# Altium schematic sheet Sheet1.SchDoc(Sheet1)
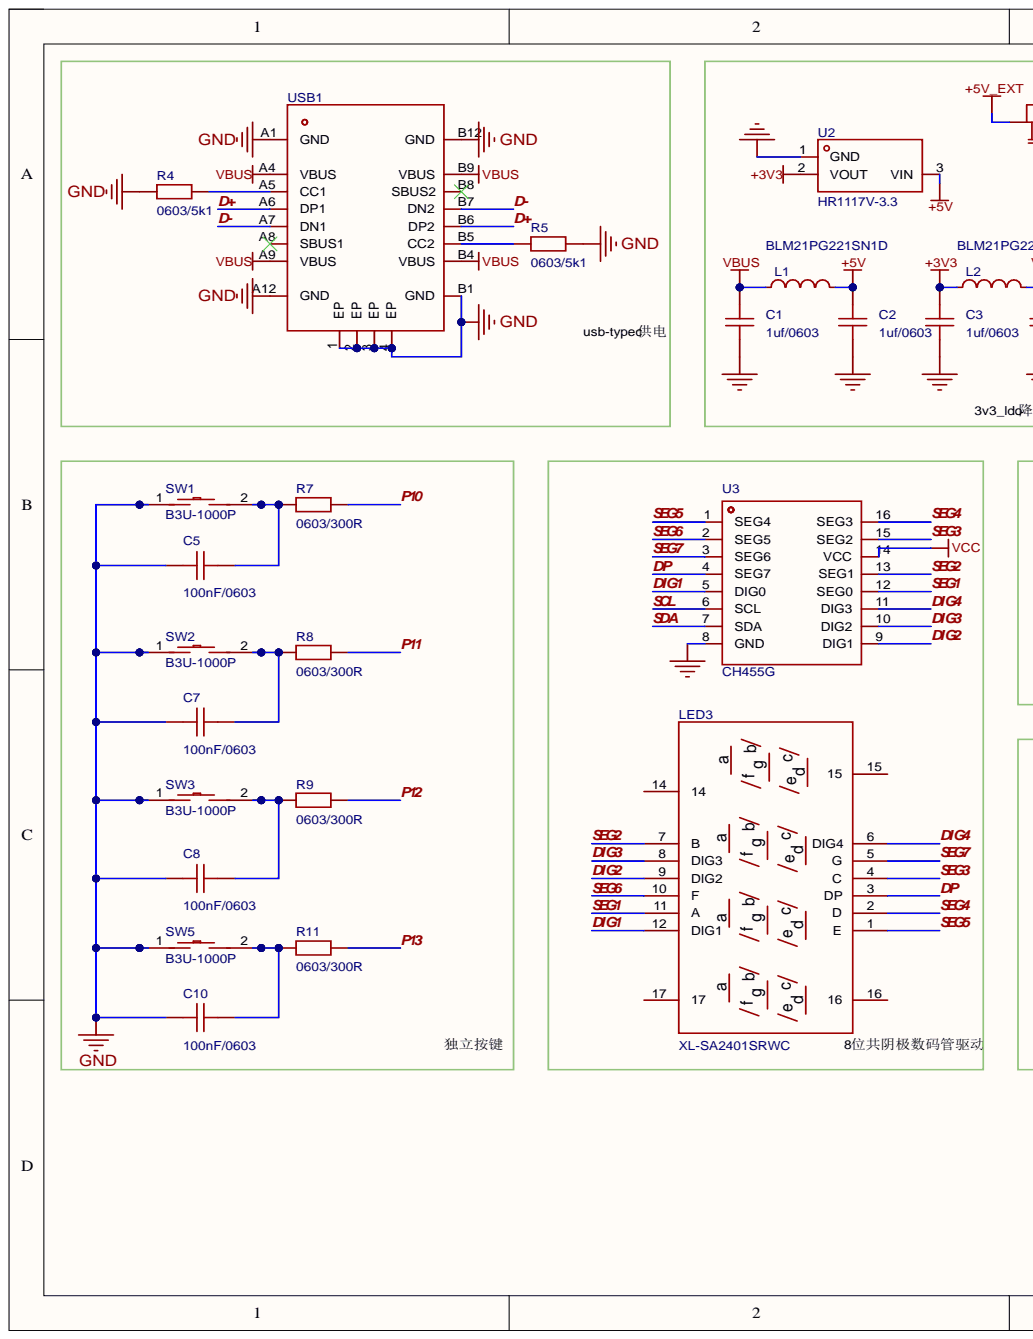
[diagram p0: Sheet1.SchDoc, left]
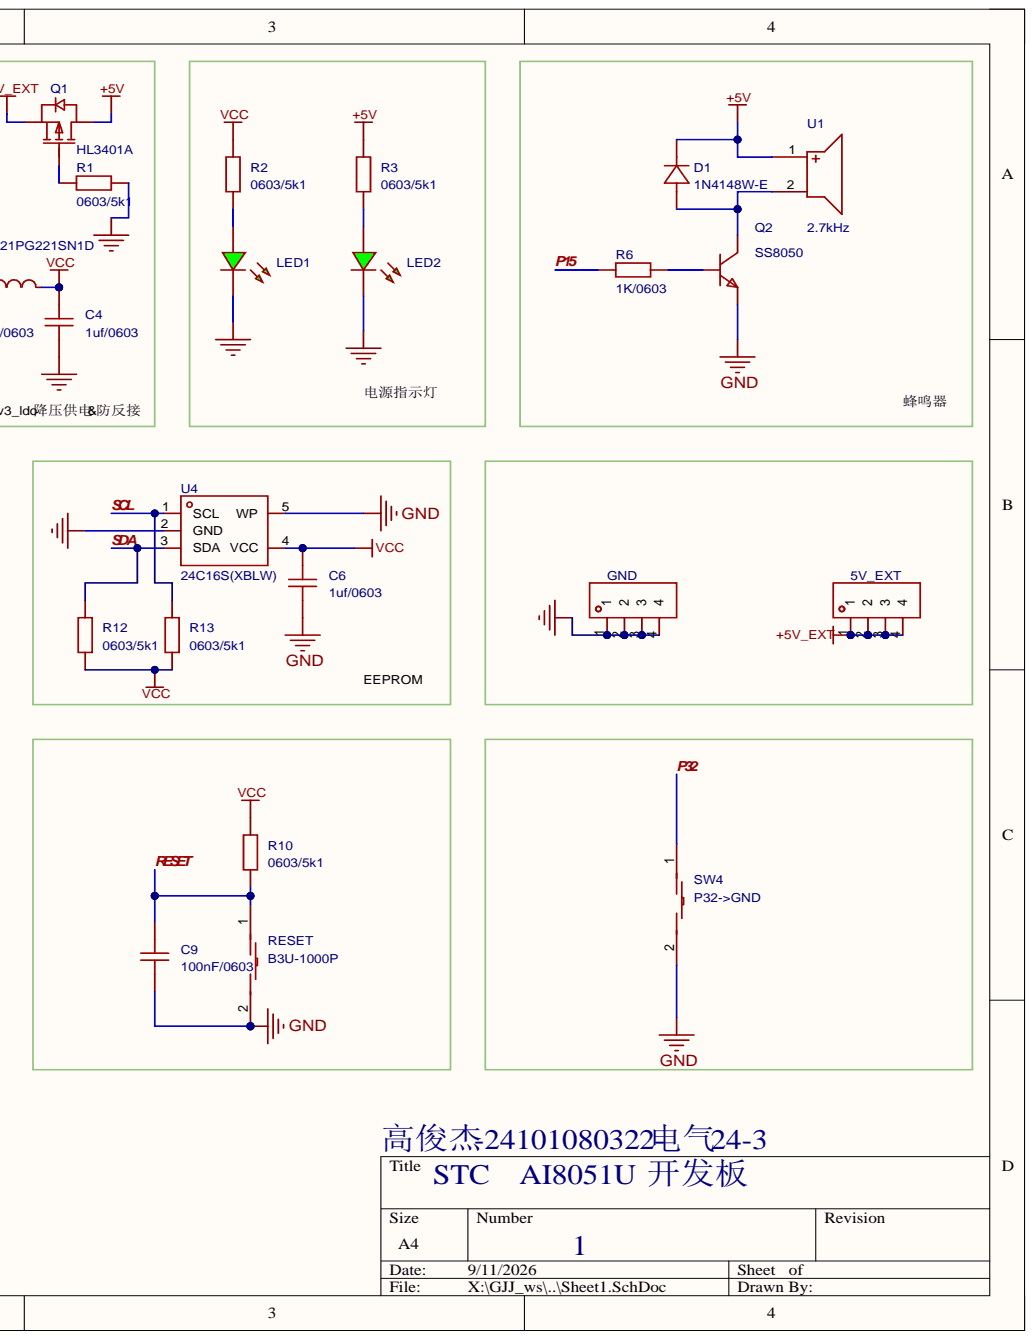
[diagram p1: Sheet1.SchDoc, right]

## Parts
5V_EXT  (no value)  [HDR-TH_4P-P2.54-V-F]
C1  1uf/0603  [C0603]
C2  1uf/0603  [C0603]
C3  1uf/0603  [C0603]
C4  1uf/0603  [C0603]
C5  100nF/0603  [C0603]
C6  1uf/0603  [C0603]
C7  100nF/0603  [C0603]
C8  100nF/0603  [C0603]
C9  100nF/0603  [C0603]
C10  100nF/0603  [C0603]
D1  1N4148W-E  [SOD-123_L2.8-W1.6-LS3.7-RD]
GND  (no value)  [HDR-TH_4P-P2.54-V-F]
L1  BLM21PG221SN1D  [L0805]
L2  BLM21PG221SN1D  [L0805]
LED1  (no value)  [LED_0603]
LED2  (no value)  [LED_0603]
LED3  XL-SA2401SRWC  [LED-SEG-SMD_12P-L28.5-W10.0-P2.54]
Q1  HL3401A  [SOT-23-3_L2.9-W1.3-P1.90-LS2.4-BR]
Q2  SS8050  [SOT-23-3_L2.9-W1.3-P1.90-LS2.4-BR]
R1  0603/5k1  [R0603]
R2  0603/5k1  [R0603]
R3  0603/5k1  [R0603]
R4  0603/5k1  [R0603]
R5  0603/5k1  [R0603]
R6  1K/0603  [R0603]
R7  0603/300R  [R0603]
R8  0603/300R  [R0603]
R9  0603/300R  [R0603]
R10  0603/5k1  [R0603]
R11  0603/300R  [R0603]
R12  0603/5k1  [R0603]
R13  0603/5k1  [R0603]
RESET  B3U-1000P  [KEY-SMD_B3U-1000PM]
SW1  B3U-1000P  [KEY-SMD_B3U-1000PM]
SW2  B3U-1000P  [KEY-SMD_B3U-1000PM]
SW3  B3U-1000P  [KEY-SMD_B3U-1000PM]
SW4  P32->GND  [KEY-SMD_B3U-1000PM]
SW5  B3U-1000P  [KEY-SMD_B3U-1000PM]
U1  2.7kHz  [BUZ-SMD_L9.7-W9.7]
U2  HR1117V-3.3  [SOT-89-3_L4.5-W2.4-P1.50-LS4.0-BR]
U3  CH455G  [SOP-16_L10.0-W3.9-P1.27-LS6.0-BL]
U4  24C16S(XBLW)  [SOT-23-5_L2.9-W1.6-P0.95-LS2.8-BL]
USB1  (no value)  [USB_TYPE-C-16P]

## Nets
+3V3: C3.2 L2.1 U2.2
+5V: C2.2 D1.1 L1.2 Q1.3 R3.2 U1.1 U2.3
+5V_EXT: 5V_EXT.1 5V_EXT.2 5V_EXT.3 5V_EXT.4 Q1.2
GND: C1.1 C2.1 C3.1 C4.1 C5.1 C6.1 C7.1 C8.1 C9.1 C10.1 GND.1 GND.2 GND.3 GND.4 LED1.1 LED2.1 Q2.2 R1.2 R4.1 R5.2 RESET.2 SW1.1 SW2.1 SW3.1 SW4.2 SW5.1 U2.1 U3.8(GND) U4.2(GND) U4.5(WP) USB1.1(EP) USB1.2(EP) USB1.3(EP) USB1.4(EP) USB1.A1(GND) USB1.A12(GND) USB1.B1(GND) USB1.B12(GND)
VBUS: C1.2 L1.1 USB1.A4(VBUS) USB1.A9(VBUS) USB1.B4(VBUS) USB1.B9(VBUS)
VCC: C4.2 C6.2 L2.2 R2.2 R10.2 R12.1 R13.1 U3.14(VCC) U4.4(VCC)
D+: USB1.A6(DP1) USB1.B6(DP2)
D-: USB1.A7(DN1) USB1.B7(DN2)
DIG1: LED3.12(DIG1) U3.5(DIG0)
DIG2: LED3.9(DIG2) U3.9(DIG1)
DIG3: LED3.8(DIG3) U3.10(DIG2)
DIG4: LED3.6(DIG4) U3.11(DIG3)
DP: LED3.3(DP) U3.4(SEG7)
P10: R7.2
P11: R8.2
P12: R9.2
P13: R11.2
P15: R6.1
P32: SW4.1
RESET: C9.2 R10.1 RESET.1
SCL: R13.2 U3.6(SCL) U4.1(SCL)
SDA: R12.2 U3.7(SDA) U4.3(SDA)
SEG1: LED3.11(A) U3.12(SEG0)
SEG2: LED3.7(B) U3.13(SEG1)
SEG3: LED3.4(C) U3.15(SEG2)
SEG4: LED3.2(D) U3.16(SEG3)
SEG5: LED3.1(E) U3.1(SEG4)
SEG6: LED3.10(F) U3.2(SEG5)
SEG7: LED3.5(G) U3.3(SEG6)
NetC5_2: C5.2 R7.1 SW1.2
NetC7_2: C7.2 R8.1 SW2.2
NetC8_2: C8.2 R9.1 SW3.2
NetC10_2: C10.2 R11.1 SW5.2
NetD1_2: D1.2 Q2.3 U1.2
NetLED1_2: LED1.2 R2.1
NetLED2_2: LED2.2 R3.1
NetQ1_1: Q1.1 R1.1
NetQ2_1: Q2.1 R6.2
NetR4_2: R4.2 USB1.A5(CC1)
NetR5_1: R5.1 USB1.B5(CC2)

Not connected: LED3 14 15 16 17; USB1 A8(SBUS1) B8(SBUS2)

## Library data of the parts
5V_EXT GND = HDR-F_2.54_1x4P: AddInBom: yes; ModelId: d187812051054f85879fcaa51a310d8e聨0819f05c4eef4c71ace90d822a990e87; ModelTransform: 419.6842,98.464,0,0,0,0,0,0,-118.11; Symbol: 2.54-1*4P母; Component Kind: Standard; ConvertToPcb: yes; Device: HDR-F_2.54_1x4P; FootprintName: HDR-TH_4P-P2.54-V-F; ModelName: HDR-TH_4P-P2.54-V-F; Supplier Footprint: 插件; SupplierPart: C2718488
C1-C10 = CAP_0603: 3D Model: ac125912035d415993ca9572d50418b1聨0819f05c4eef4c71ace90d822a990e87; 3D Model Transform: 62.992,31.496,0,0,0,0,0,0,0; AddInBom: yes; ModelName: C0603_L1.6-W0.8-H0.8; Symbol: Capacitance; Component Kind: Standard; ConvertToPcb: yes; Device: CAP_0603; FootprintName: C0603; PCB3D: C0603_L1.6-W0.8-H0.8; Supplier Footprint: 0603
  C1-C4 C6: Value: 1uf/0603
  C5 C7-C10: Value: 100nF/0603
D1 = 1N4148W-E: 二极管配置:-;正向压降(Vf):-;直流反向耐压(Vr):-;; Manufacturer: MDD(辰达半导体); 3D Model: 73858e86c18848f5a81710cfe7352ee5; 3D Model Transform: 145.669,70.3249,0,0,0,0,0,0,0; AddInBom: yes; Component Kind: Standard; ConvertToPcb: yes; Datasheet: https://atta.szlcsc.com/upload/public/pdf/source/20240830/DACFAB1CEFECD76C0C0B0085B83E27DC.pdf; Device: 1N4148W-E; Diode Configuration: -; FootprintName: SOD-123_L2.8-W1.6-LS3.7-RD; Forward Voltage (Vf@If): -; JLCPCB Part Class: 扩展库; LCSC Part Name: 开关二极管; Manufacturer Part: 1N4148W-E; ModelName: SOD-123_L2.8-W1.6-LS3.7-RD; PCB3D: SOD-123_L2.8-W1.6-LS3.7-RD; Reverse Voltage (Vr): -; Supplier: LCSC; Supplier Footprint: SOD-123; Supplier Part: C41371420; Symbol: 1n4148w-e
L1 L2 = BLM21PG221SN1D: 阻抗@频率:220Ω@100MHz;误差:±25%;直流电阻(DCR):45mΩ;; Manufacturer: muRata(村田); AddInBom: yes; Current Rating: 2A; DC Resistance(DCR): 45mΩ; ModelId: 37a81e68749a4138bd2dfa6897b24b85聨0819f05c4eef4c71ace90d822a990e87; ModelName: R0805_L2.0-W1.3-H0.6; ModelTransform: 78.74,51.181,0,0,0,0,0,0.001,0; Number of Circuits: 1; Tolerance: ±25%; Component Kind: Standard; ConvertToPcb: yes; Datasheet: https://item.szlcsc.com/datasheet/BLM21PG221SN1D/87016.html; Device: BLM21PG221SN1D; FootprintName: L0805; Impedance @ Frequency: 220Ω@100MHz; JLCPCB Part Class: Extended Part; LCSC Part Name: 单路 0805磁珠 阻抗220Ω@100MHz; ManufacturerPart: BLM21PG221SN1D; Operating Temperature: -55℃~+125℃; Supplier: LCSC; Supplier Footprint: 0805; SupplierPart: C85840; Symbol: BLM21PG221SN1D
LED1 LED2 = LED_0603-G: 3D Model: 8b5fcaf0229049f8a37a9c352bb0ca0a聨0819f05c4eef4c71ace90d822a990e87; 3D Model Transform: 63.1,31.6,23.7,0,0,0,0,0,0; Add into BOM: yes; ModelName: LED-0603_G; Symbol: LED_G_OLD; Component Kind: Standard; Convert to PCB: yes; Device: LED_0603-G; FootprintName: LED_0603; Supplier Footprint: 0603
LED3 = XL-SA2401SRWC: 数码管位数:4位;字符尺寸(英寸):0.2;LED极性:共阴;发光颜色:红色;耗散功率(Pd):36mW;; Manufacturer: XINGLIGHT(成兴光); AddInBom: yes; Digit/Alpha Size(inch): 0.2; Display Type: 7段; Forward Voltage Drop: 1.8V; Illumination Color: 红色; LED Polarity: 共阴; Light Intensity: 100mcd; ModelId: 84030f14c7a848788791f3033a3ddaf3聨0819f05c4eef4c71ace90d822a990e87; ModelTransform: 1122.045,393.7,0,0,0,0,0,0,0; Number of Characters: 4位; Pd - Power Dissipation: 36mW; Component Kind: Standard; ConvertToPcb: yes; Datasheet: https://item.szlcsc.com/datasheet/XL-SA2401SRWC/52210392.html; Device: XL-SA2401SRWC; FootprintName: LED-SEG-SMD_12P-L28.5-W10.0-P2.54; Height: -; JLCPCB Part Class: Extended Part; LCSC Part Name: 0.2英寸红色四位共阴数码管; Length: -; ManufacturerPart: XL-SA2401SRWC; ModelName: LED-SEG-SMD_12P-L28.5-W10.0-P2.54; Operating Temperature: -30℃~+70℃; Supplier: LCSC; Supplier Footprint: SMD; SupplierPart: C49652871; Symbol: XL-SA2401SRWC; Width: -
Q1 = HL3401A: 数量:1个P沟道;漏源电压(Vdss):30V;连续漏极电流(Id):4.2A;导通电阻(RDS(on)):50mΩ@10V;耗散功率(Pd):350mW;阈值电压(Vgs(th)):900mV@250uA;; Manufacturer: R+O(宏嘉诚); AddInBom: yes; Current - Continuous Drain(Id): 4.2A; Drain to Source Voltage: 30V; Gate Charge(Qg): 22nC@10V; Input Capacitance(Ciss): 954pF; ModelId: c62791f0466c4ae2a892c056b845b0ca聨0819f05c4eef4c71ace90d822a990e87; ModelName: SOT-23-3P_L2.9-W1.3-H1.0-LS2.4-P0.95; ModelTransform: 98.425,114.173,0,180,0,0,0.0005,-0.0005,0; Number: 1个P沟道; Output Capacitance(Coss): 115pF; Pd - Power Dissipation: 350mW; Reverse Transfer Capacitance (Crss@Vds): 77pF; Type: P沟道; Component Kind: Standard; ConvertToPcb: yes; Datasheet: https://item.szlcsc.com/datasheet/HL3401A/8397171.html; Device: HL3401A; FootprintName: SOT-23-3_L2.9-W1.3-P1.90-LS2.4-BR; Gate Threshold Voltage (Vgs(th)): 900mV@250uA; JLCPCB Part Class: Extended Part; LCSC Part Name: 1个P沟道 耐压:30V 电流:4.2A; ManufacturerPart: HL3401A; Operating Temperature: -55℃~+150℃; RDS(on): 50mΩ@10V; Supplier: LCSC; Supplier Footprint: SOT-23; SupplierPart: C7420349; Symbol: HL3401A
Q2 = SS8050_C2150: 晶体管类型:NPN;集电极电流(Ic):1.5A;集射极击穿电压(Vceo):25V;功率(Pd):300mW;直流电流增益(hFE@Ic,Vce):200@100mA,1V;; Manufacturer: CJ(江苏长电/长晶); 3D Model: c62791f0466c4ae2a892c056b845b0ca; 3D Model Transform: 98.425,114.173,0,180,0,0,0.0005,-0.0005,0; AddInBom: yes; Collector Current (Ic): 1.5A; Collector Cut-Off Current (Icbo): 100nA; Collector-Emitter Breakdown Voltage (Vceo): 25V; Collector-Emitter Saturation Voltage (VCE(sat)@Ic,Ib): 500mV@800mA,80mA; ModelName: SOT-23-3P_L2.9-W1.3-H1.0-LS2.4-P0.95; Power Dissipation (Pd): 300mW; Transistor Type: NPN; Transition Frequency (fT): 100MHz; Component Kind: Standard; ConvertToPcb: yes; Datasheet: https://atta.szlcsc.com/upload/public/pdf/source/20230322/AEE86F4B043774EFF80CAEA14A37D9E9.pdf; DC Current Gain (hFE@Ic,Vce): 200@100mA,1V; Device: SS8050_C2150; FootprintName: SOT-23-3_L2.9-W1.3-P1.90-LS2.4-BR; LCSC Part Name: SS8050(RANGE:200-350) hfe=200~350; Manufacturer Part: SS8050; Operating Temperature: -; PCB3D: SOT-23-3P_L2.9-W1.3-H1.0-LS2.4-P0.95; Supplier: LCSC; Supplier Footprint: SOT-23; Supplier Part: C2150; Symbol: SS8050_C2150
R1-R13 = Res_0603: AddInBom: yes; ModelId: fc143cca1e79419f9cd78b61e9279f08聨0819f05c4eef4c71ace90d822a990e87; ModelTransform: 31.8897,63.7794,0,90,0,0,0,0,0; Symbol: 电阻; Component Kind: Standard; ConvertToPcb: yes; Device: Res_0603; FootprintName: R0603; ModelName: R0603; Supplier Footprint: 0603
  R1-R5 R10 R12 R13: Value: 0603/5k1
  R6: Value: 1K/0603
  R7-R9 R11: Value: 0603/300R
RESET SW1-SW5 = B3U-1000P: 电路结构:单刀单掷;按钮形状:圆形按钮;作用力:1.53N;安装方式:立贴;开关长度:3mm;开关宽度:2.5mm;开关高度:1.6mm;触点电流:50mA;额定电压:12V;带灯:-;工作寿命:-;; Manufacturer: OMRON(欧姆龙); Actuator Style: 圆形按钮; AddInBom: yes; Circuit: 单刀单掷; Contact Current: 50mA; ModelId: 4a122f942e8c428cab657e97b0b9ae70聨0819f05c4eef4c71ace90d822a990e87; ModelName: KEY-SMD_2P-L3.0-W2.5-H1.6-LS4.0; ModelTransform: 157.48,98.425,0,0,0,0,0,0,0; Operating Force: 1.53N; Switch Height: 1.6mm; Voltage Rating: 12V; Component Kind: Standard; ConvertToPcb: yes; Datasheet: https://item.szlcsc.com/datasheet/B3U-1000P/231216.html; Device: B3U-1000P; FootprintName: KEY-SMD_B3U-1000PM; JLCPCB Part Class: Extended Part; LCSC Part Name: 3*2.5*1.6mm 立贴 轻触开关; Length: 3mm; Life: -; ManufacturerPart: B3U-1000P; Mounting Type: 立贴; Operating Temperature: -25℃~+70℃; Supplier: LCSC; Supplier Footprint: SMD,2.5x3mm; SupplierPart: C231329; Symbol: B3U-1000P; Termination Style: SMD接片; Width: 2.5mm; With Lamp: -
U1 = CMI-9705-0580-SMT-TR: 驱动方式:有源(内含驱动线路);构造类型:电磁式;额定电压:5V;频率:2.7kHz;声压(SPL):80dB;; Manufacturer: CUI; AddInBom: yes; Current Consumption: 30mA; ModelId: 4d30b5b9f6214c52b026a489d6e841ad聨0819f05c4eef4c71ace90d822a990e87; ModelTransform: 382.283,397.637,0,0,0,0,0,-0.001,0; Sound Pressure Level(SPL): 80dB; Voltage - Supply: 3V~8V; Voltage Rating: 5V; Component Kind: Standard; Continuous Operating Time: -; ConvertToPcb: yes; Datasheet: https://item.szlcsc.com/datasheet/CMI-9705-0580-SMT-TR/23802189.html; Device: CMI-9705-0580-SMT-TR; Diameter(φD): -; Driver Circuitry: 有源(内含驱动线路); FootprintName: BUZ-SMD_L9.7-W9.7; Height: -; JLCPCB Part Class: Extended Part; LCSC Part Name: 磁性蜂鸣器指示器，表面贴装，内部驱动; Lead Pitch: -; Length: -; ManufacturerPart: CMI-9705-0580-SMT-TR; ModelName: BUZ-SMD_L9.7-W9.7; Mounting Type: -; Operating Temperature: -; Supplier: LCSC; Supplier Footprint: SMD,9.7x9.7mm; SupplierPart: C22359716; Symbol: CMI-9705-0580-SMT-TR; Technology: 电磁式; Value: 2.7kHz; Width: -
U2 = HR1117V-3.3: 输出极性:正极;工作电压:15V;输出电压:3.3V;输出电流:1A;电源纹波抑制比(PSRR):-;输出类型:固定;; Manufacturer: R+O(宏嘉诚); AddInBom: yes; ModelId: dde435042bae4b9691e74da94cf9d9f3聨0819f05c4eef4c71ace90d822a990e87; ModelTransform: 177.165,161.417,0,90,0,0,-2.323,0,0; Noise: 0.003%Vout; Number of Outputs: 1; Output Configuration: 正极; Output Current: 1A; Output Type: 固定; Output Voltage: 3.3V; standby current: 2mA; Voltage - Supply: 15V; Voltage Dropout: 1.1V@(1A); Component Kind: Standard; ConvertToPcb: yes; Datasheet: https://item.szlcsc.com/datasheet/HR1117V-3.3/57930308.html; Device: HR1117V-3.3; Features: 热关断; FootprintName: SOT-89-3_L4.5-W2.4-P1.50-LS4.0-BR; JLCPCB Part Class: Extended Part; LCSC Part Name: 低压差线性稳压器，分固定电压输出和可调电压输出版本; ManufacturerPart: HR1117V-3.3; ModelName: SOT-89-3_L4.5-W2.4-P1.50-LS4.0-BR; Operating Temperature: -40℃~+85℃; Power Supply Rejection Ratio (PSRR): -; Supplier: LCSC; Supplier Footprint: SOT-89; SupplierPart: C54560864; Symbol: HR1117V-3.3
U3 = CH455G: 接口类型:I2C;工作电压:2.7V~5V;时钟频率(fc):4MHz;位驱动电流:160mA;段驱动电流:25mA;; Manufacturer: WCH(南京沁恒); AddInBom: yes; Clock Frequency: 4MHz; Configuration: 7段x4位；8段x4位; Digit Drive Current: 160mA; Interface: I2C; ModelId: 3361a6792abf4a8f8fbca4f1924a67ee聨0819f05c4eef4c71ace90d822a990e87; ModelName: SOP-16_L9.9-W3.9-H1.5-LS6.0-P1.27; ModelTransform: 240.39054,393.7,0,90,0,0,0,0,0; Quiescent Current: 50uA; Segment Drive Current: 25mA; Component Kind: Standard; ConvertToPcb: yes; Datasheet: https://item.szlcsc.com/datasheet/CH455G/91000.html; Device: CH455G; Features: 键盘扫描；共阴极；辉光可调; FootprintName: SOP-16_L10.0-W3.9-P1.27-LS6.0-BL; JLCPCB Part Class: Extended Part; LCSC Part Name: 数码管驱动及键盘控制芯片; ManufacturerPart: CH455G; Operating Temperature: -40℃~+85℃; Pd - Power Dissipation: -; Supplier: LCSC; Supplier Footprint: SOP-16; SupplierPart: C89818; Symbol: CH455G; Voltage - Supply: 2.7V~5V
U4 = 24C16S(XBLW): 接口类型:I2C;存储容量:16Kbit;时钟频率(fc):1MHz;; Manufacturer: XBLW(芯伯乐); AddInBom: yes; Clock Frequency: 1MHz; Data Retention - TDR (Year): 100年; Interface: I2C; Memory Size: 16Kbit; ModelId: 018c57e721114987ae90467fe9f1d259聨0819f05c4eef4c71ace90d822a990e87; ModelTransform: 114.96,110.236,0,0,0,0,0,0,0; Voltage - Supply: 1.8V~5.5V; Write Cycle Endurance: 100万次; Write Cycle Time(Tw): 5ms; Component Kind: Standard; ConvertToPcb: yes; Datasheet: https://item.szlcsc.com/datasheet/24C16S(XBLW)/43210132.html; Device: 24C16S(XBLW); Features: 内置上电复位(POR)；硬件写保护功能；噪声抑制功能; FootprintName: SOT-23-5_L2.9-W1.6-P0.95-LS2.8-BL; JLCPCB Part Class: Extended Part; LCSC Part Name: 16Kbit 串行EEPROM; ManufacturerPart: 24C16S(XBLW); ModelName: SOT-23-5_L2.9-W1.6-P0.95-LS2.8-BL; Operating Temperature: -40℃~+85℃; Supplier: LCSC; Supplier Footprint: SOT-23-5; SupplierPart: C41408503; Symbol: 24C16S(XBLW)
USB1 = USB_TYPE-C-16P: AddInBom: yes; ModelId: 35058e297fd54ee2afd2de30c3ce7155聨0819f05c4eef4c71ace90d822a990e87; ModelName: USB-C_SMD-TYPE-C-31-M-12; ModelTransform: 360,318.121,0,180,0,0,0,-41.02,-39.37; Component Kind: Standard; ConvertToPcb: yes; Device: USB_TYPE-C-16P; FootprintName: USB_TYPE-C-16P; Supplier Footprint: SMD; Symbol: USB_TYPE-C-16P
